# Altium schematic sheet 按键板.SchDoc
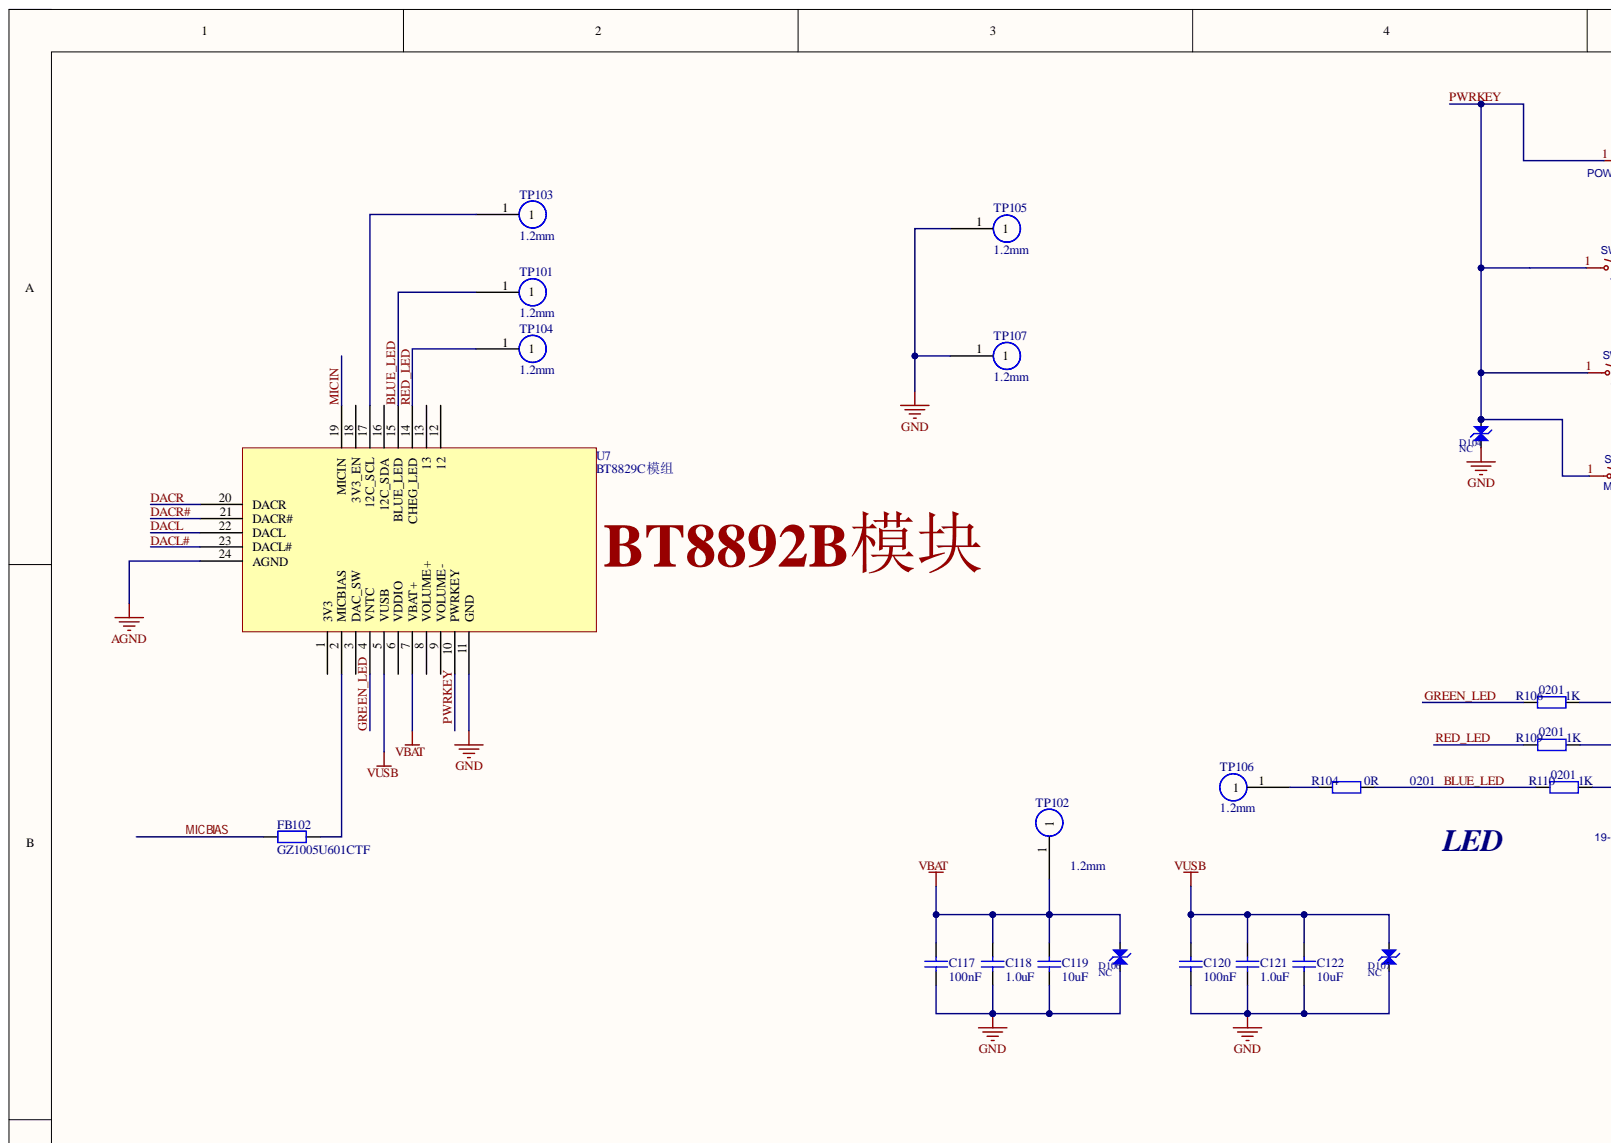
[diagram p0: 按键板.SchDoc, top left]
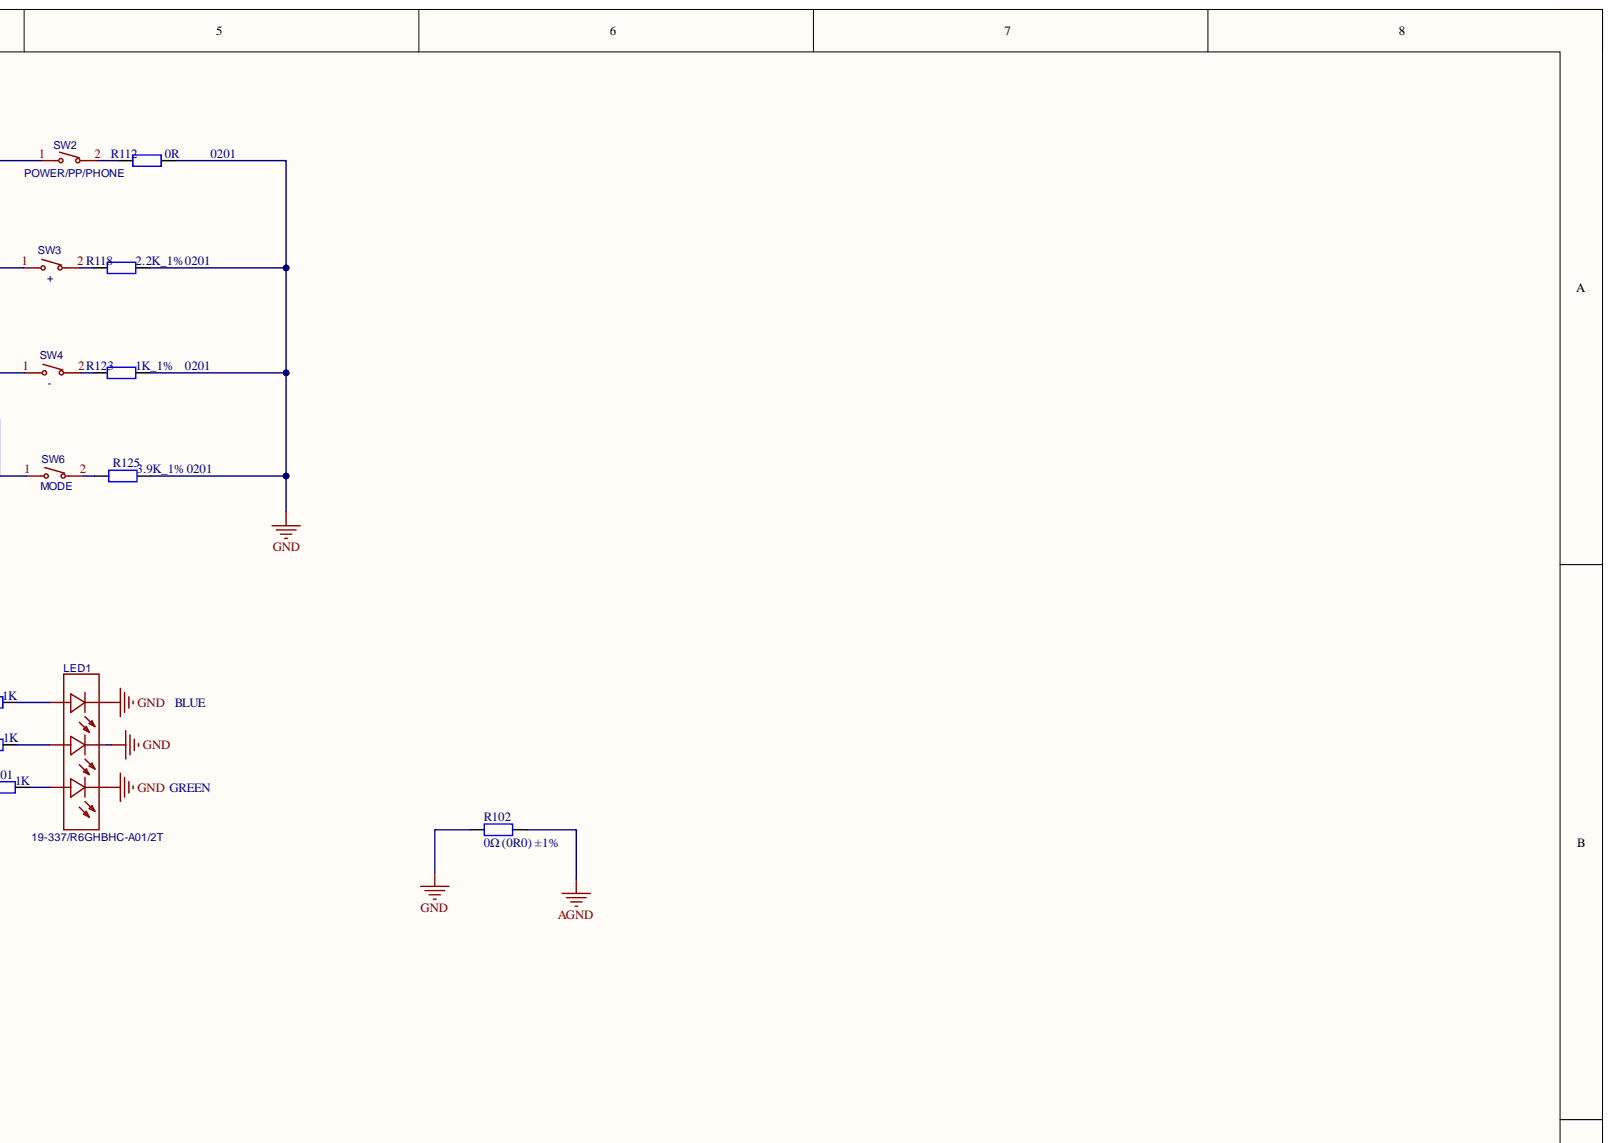
[diagram p1: 按键板.SchDoc, top right]
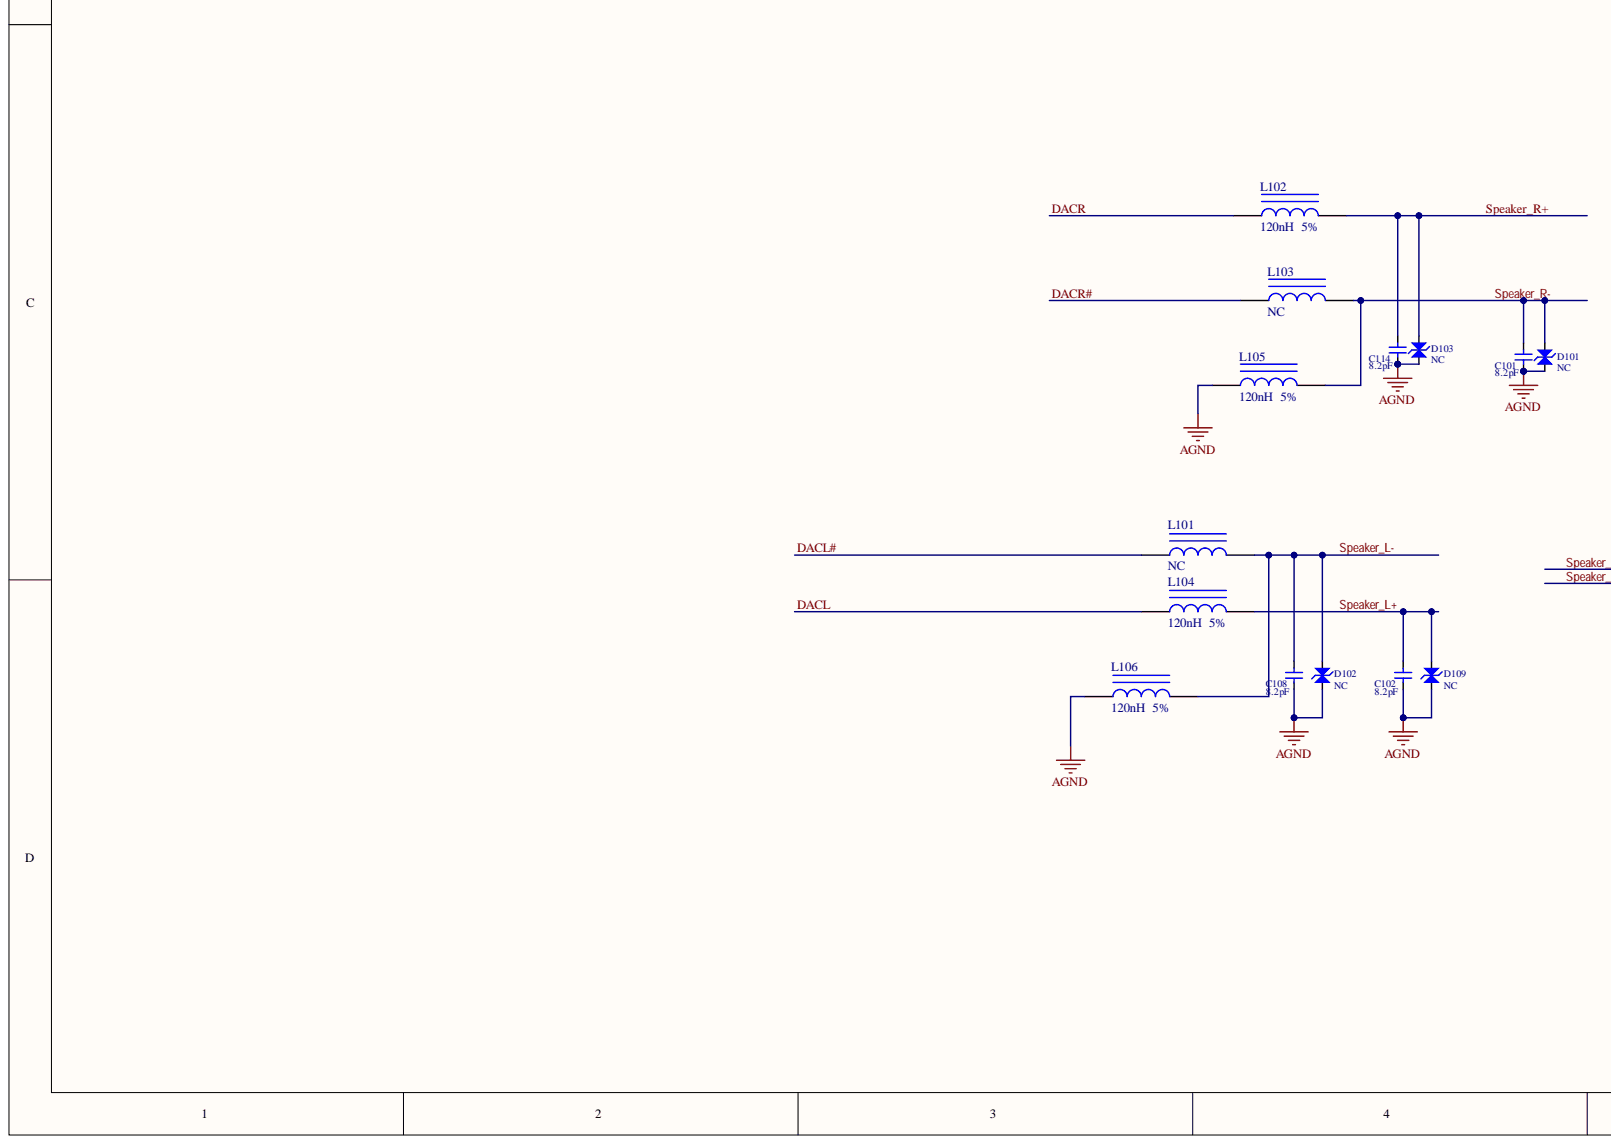
[diagram p2: 按键板.SchDoc, bottom left]
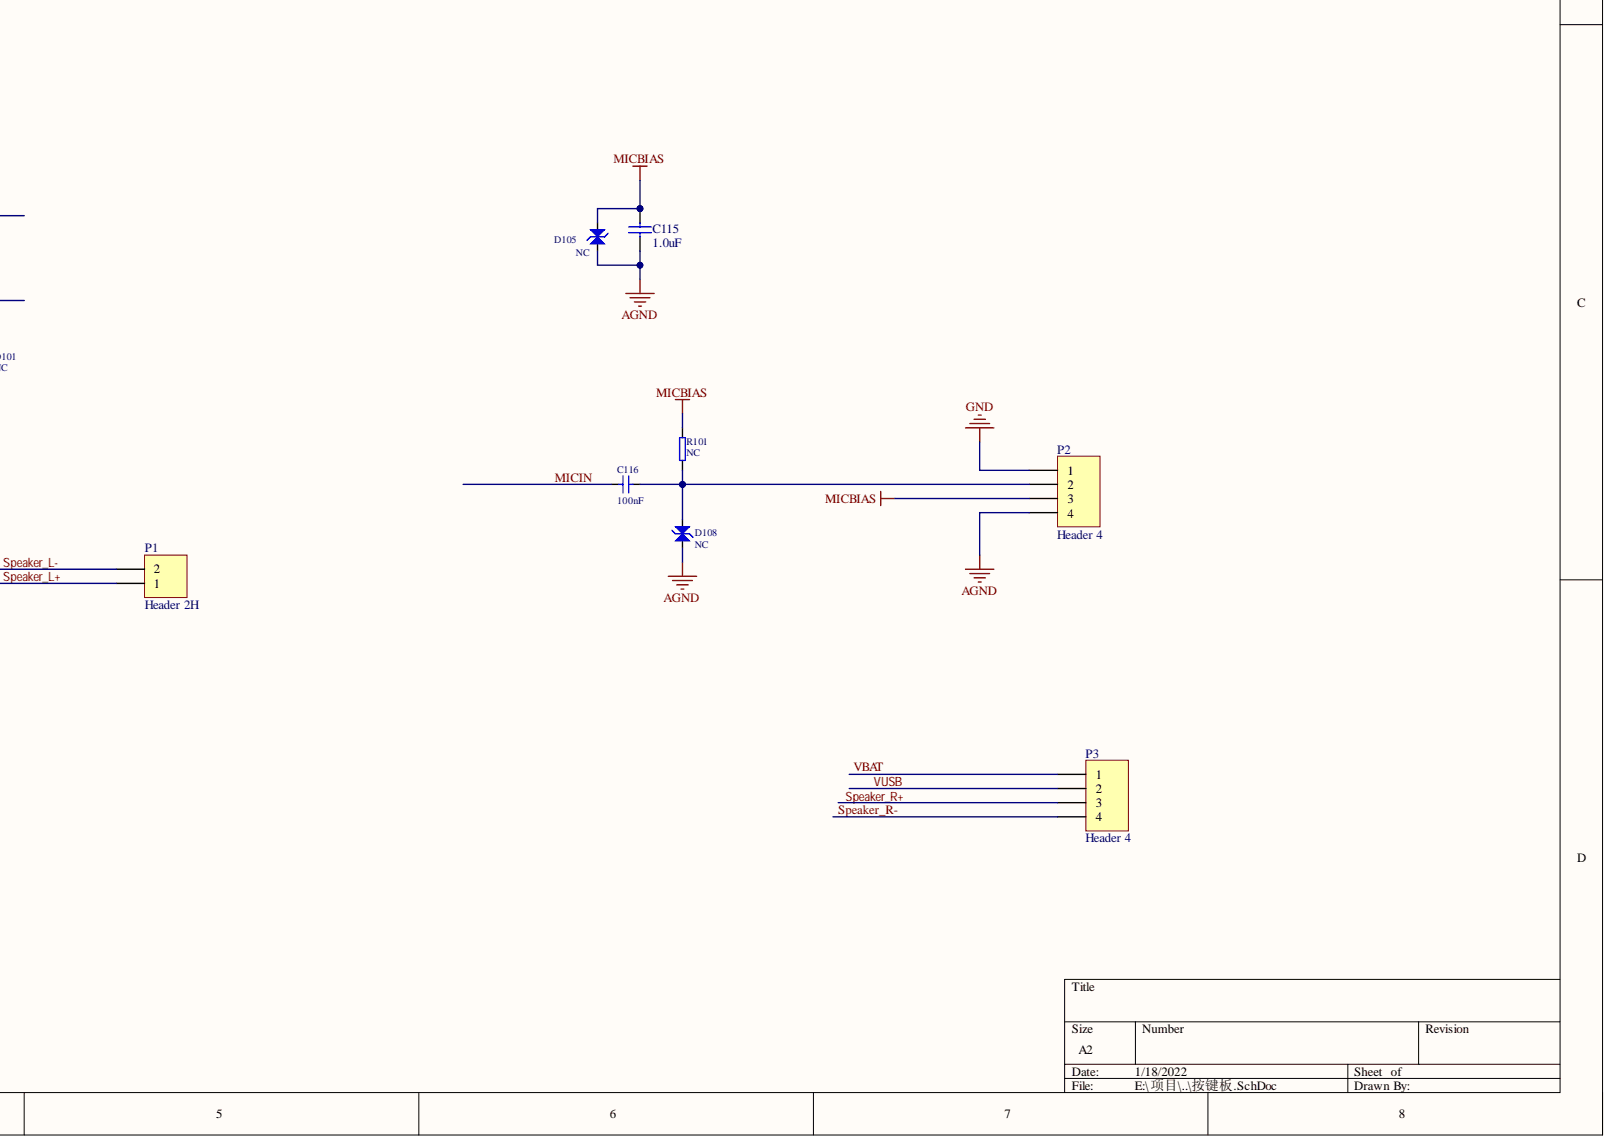
[diagram p3: 按键板.SchDoc, bottom right]

## Parts
C101  8.2pF  [0402_C]
C102  8.2pF  [0402_C]
C108  8.2pF  [0402_C]
C114  8.2pF  [0402_C]
C115  1.0uF  [0402_C]
C116  100nF  [0402_C]
C117  100nF  [0402_C]
C118  1.0uF  [0402_C]
C119  10uF  [0402_C]
C120  100nF  [0402_C]
C121  1.0uF  [0402_C]
C122  10uF  [0402_C]
D101  NC  [TVS]
D102  NC  [TVS]
D103  NC  [TVS]
D104  NC  [TVS]
D105  NC  [TVS]
D106  NC  [TVS]
D107  NC  [TVS]
D108  NC  [TVS]
D109  NC  [TVS]
FB102  GZ1005U601CTF  [0402_R]
L101  NC  [0402_L]
L102  120nH  5%  [0402_L]
L103  NC  [0402_L]
L104  120nH  5%  [0402_L]
L105  120nH  5%  [0402_L]
L106  120nH  5%  [0402_L]
LED1  19-337/R6GHBHC-A01/2T  [LED-ARRAY-SMD_6P-L1.6-W1.6-TL]
P1  Header 2H  [2PIN_PAD]
P2  Header 4  [4PINPAD_1]
P3  Header 4  [4PINPAD_1]
R101  NC  [0402_R]
R102  0Ω (0R0) ±1%  [0603_R]
R104  0R  Package: 0201  [0402_R]
R106  1K  Package: 0201  [0402_R]
R109  1K  Package: 0201  [0402_R]
R110  1K  Package: 0201  [0402_R]
R112  0R  Package: 0201  [0402_R]
R118  2.2K_1%  Package: 0201  [0402_R]
R123  1K_1%  Package: 0201  [0402_R]
R125  3.9K_1%  Package: 0201  [0402_R]
SW2  POWER/PP/PHONE  [SW-SMD_L3.0-W2.0-LS3.5]
SW3  +  [SW-SMD_L3.0-W2.0-LS3.5]
SW4  -  [SW-SMD_L3.0-W2.0-LS3.5]
SW6  MODE  [SW-SMD_L3.0-W2.0-LS3.5]
TP101  1.2mm  [1.2mm测试点]
TP102  1.2mm  [1.2mm测试点]
TP103  1.2mm  [1.2mm测试点]
TP104  1.2mm  [1.2mm测试点]
TP105  1.2mm  [1.2mm测试点]
TP106  1.2mm  [1.2mm测试点]
TP107  1.2mm  [1.2mm测试点]
U7  BT8829C模组  [8829C-MODU1]

## Nets
AGND: C101.1 C102.1 C108.1 C114.1 C115.1 D101.1 D102.1 D103.1 D105.1 D108.1 D109.1 L105.1 L106.1 P2.4 R102.2 U7.24(AGND)
GND: C117.1 C118.1 C119.1 C120.1 C121.1 C122.1 D104.1 D106.1 D107.1 LED1.1 LED1.2 LED1.3 P2.1 R102.1 R112.2 R118.2 R123.2 R125.2 TP105.1 TP107.1 U7.11(GND)
BLUE_LED: R104.2 R110.1 TP101.1 U7.15(BLUE_LED)
DACL: L104.1 U7.22(DACL)
DACL#: L101.1 U7.23(DACL#)
DACR: L102.1 U7.20(DACR)
DACR#: L103.1 U7.21(DACR#)
GREEN_LED: R106.1 U7.4(VNTC)
MICBIAS: C115.2 D105.2 FB102.1 P2.3 R101.2
MICIN: C116.1 U7.19(MICIN)
PWRKEY: D104.2 SW2.1 SW3.1 SW4.1 SW6.1 U7.10(PWRKEY)
RED_LED: R109.1 TP104.1 U7.14(CHEG_LED)
Speaker_L+: C102.2 D109.2 L104.2 P1.1
Speaker_L-: C108.2 D102.2 L101.2 L106.2 P1.2
Speaker_R+: C114.2 D103.2 L102.2 P3.3
Speaker_R-: C101.2 D101.2 L103.2 L105.2 P3.4
VBAT: C117.2 C118.2 C119.2 D106.2 P3.1 TP102.1 U7.7(VBAT+)
VUSB: C120.2 C121.2 C122.2 D107.2 P3.2 U7.5(VUSB)
NetC116_2: C116.2 D108.2 P2.2 R101.1
NetFB102_2: FB102.2 U7.2(MICBIAS)
NetLED1_4: LED1.4 R110.2
NetLED1_5: LED1.5 R109.2
NetLED1_6: LED1.6 R106.2
NetR104_1: R104.1 TP106.1
NetR112_1: R112.1 SW2.2
NetR118_1: R118.1 SW3.2
NetR123_1: R123.1 SW4.2
NetR125_1: R125.1 SW6.2
NetTP103_1: TP103.1 U7.17(12C_SCL)

Not connected: U7 1(3V3) 3(DAC_SW) 6(VDDIO) 8(VOLUME+) 9(VOLUME-) 12 13 16(12C_SDA) 18(3V3_EN)

## Library data of the parts
C101 C102 C108 C114 C116 = CAP: Capacitor; Component Kind: Standard
C115 C118 C121 = 0402 1.0uF (105) 10% 25V: 贴片电容; Component Kind: Standard; ComponentLink1Description: 供应商链接; ComponentLink1URL: http://www.szlcsc.com/product/details_53938.html; Library Name: Miscellaneous Devices LC.IntLib; Package: 0402; Supplier: LC; SuppliersPartNumber: C52923
C117 C120 = 0402 100nF (104) 10% 16V: 贴片电容; Component Kind: Standard; ComponentLink1Description: 供应商链接; ComponentLink1URL: http://www.szlcsc.com/product/details_1877.html; Library Name: Miscellaneous Devices LC.IntLib; Package: 0402; Supplier: LC; SuppliersPartNumber: C1525
C119 C122 = 0402 10uF (106) 20% 6.3V: 贴片电容; Component Kind: Standard; ComponentLink1Description: 供应商链接; ComponentLink1URL: http://www.szlcsc.com/product/details_16204.html; Library Name: Miscellaneous Devices LC.IntLib; Package: 0402; Supplier: LC; SuppliersPartNumber: C15525
D101-D109 = SMB/DO-214AA P6SMB6.8CA: TVS; Component Kind: Standard; ComponentLink1Description: 供应商链接; ComponentLink1URL: http://www.szlcsc.com/product/details_79530.html; Package: SMB/DO-214AA; Supplier: LC; SuppliersPartNumber: C78395; Library Name: BT8892A QFN32_4x4 TWS蓝牙耳机V1.4.SCHLIB
FB102 = 0402 0Ω (0R0) 1%: 贴片电阻; Component Kind: Standard; ComponentLink1Description: 供应商链接; ComponentLink1URL: http://www.szlcsc.com/product/details_17853.html; Library Name: Miscellaneous Devices LC.IntLib; Package: 0402; Supplier: LC; SuppliersPartNumber: C17168
L101-L106 = 0402 12nH  5%: 贴片电感; Component Kind: Standard; Library Name: Miscellaneous Devices LC.IntLib; Package: 0402; Supplier: LC
LED1 = 19-337/R6GHBHC-A01/2T: BOM_Manufacturer: Everlight Elec; Contributor: LCSC; BOM_JLCPCB Part Class: Extended Part; BOM_Manufacturer Part: 19-337/R6GHBHC-A01/2T; BOM_Supplier: LCSC; BOM_Supplier Part: C264519; Component Kind: Standard; spicePre: L; spiceSymbolName: 19-337/R6GHBHC-A01/2T
P1 = Header 2H: Header, 2-Pin, Right Angle; Component Kind: Standard; LatestRevisionDate: 17-Jul-2002; LatestRevisionNote: Re-released for DXP Platform.; Library Name: Miscellaneous Connectors.IntLib; Signal Integrity: Connector; Publisher: Altium Limited
P2 P3 = Header 4: Header, 4-Pin; Component Kind: Standard; LatestRevisionDate: 17-Jul-2002; LatestRevisionNote: Re-released for DXP Platform.; Library Name: AD_LIB.SchLib; Publisher: Altium Limited
R101 = RES2: Component Kind: Standard
R102 = 0603 0Ω (0R0) 1%: 贴片电阻; Component Kind: Standard; ComponentLink1Description: 供应商链接; ComponentLink1URL: http://www.szlcsc.com/product/details_21903.html; Library Name: Miscellaneous Devices LC.IntLib; Package: 0603; Supplier: LC; SuppliersPartNumber: C21189
R104 R106 R109 R110 R112 R118 R123 R125 = 0201贴片电阻_10K: 贴片电阻; Component Kind: Standard; ComponentLink1Description: 供应商链接; ComponentLink1URL: http://www.szlcsc.com/product/details_26498.html; Library Name: AD_LIB.IntLib
SW2-SW4 SW6 = TS2306A 230GF  9: BOM_Manufacturer: SHOU HAN; Contributor: LCSC; BOM_JLCPCB Part Class: Extended Part; BOM_Manufacturer Part: TS2306A 230gf  9; BOM_Supplier: LCSC; BOM_Supplier Part: C388289; Component Kind: Standard; spicePre: S; spiceSymbolName: TS2306A 230GF  9
TP101-TP107 = 1.2mm测试点: Component Kind: Standard; Library Name: AD_LIB.IntLib
U7 = BT8829C模组: Component Kind: Standard; Library Name: AD_LIB.SchLib
